# Altium schematic sheet Sensorbox_Hub_ESP32.SchDoc
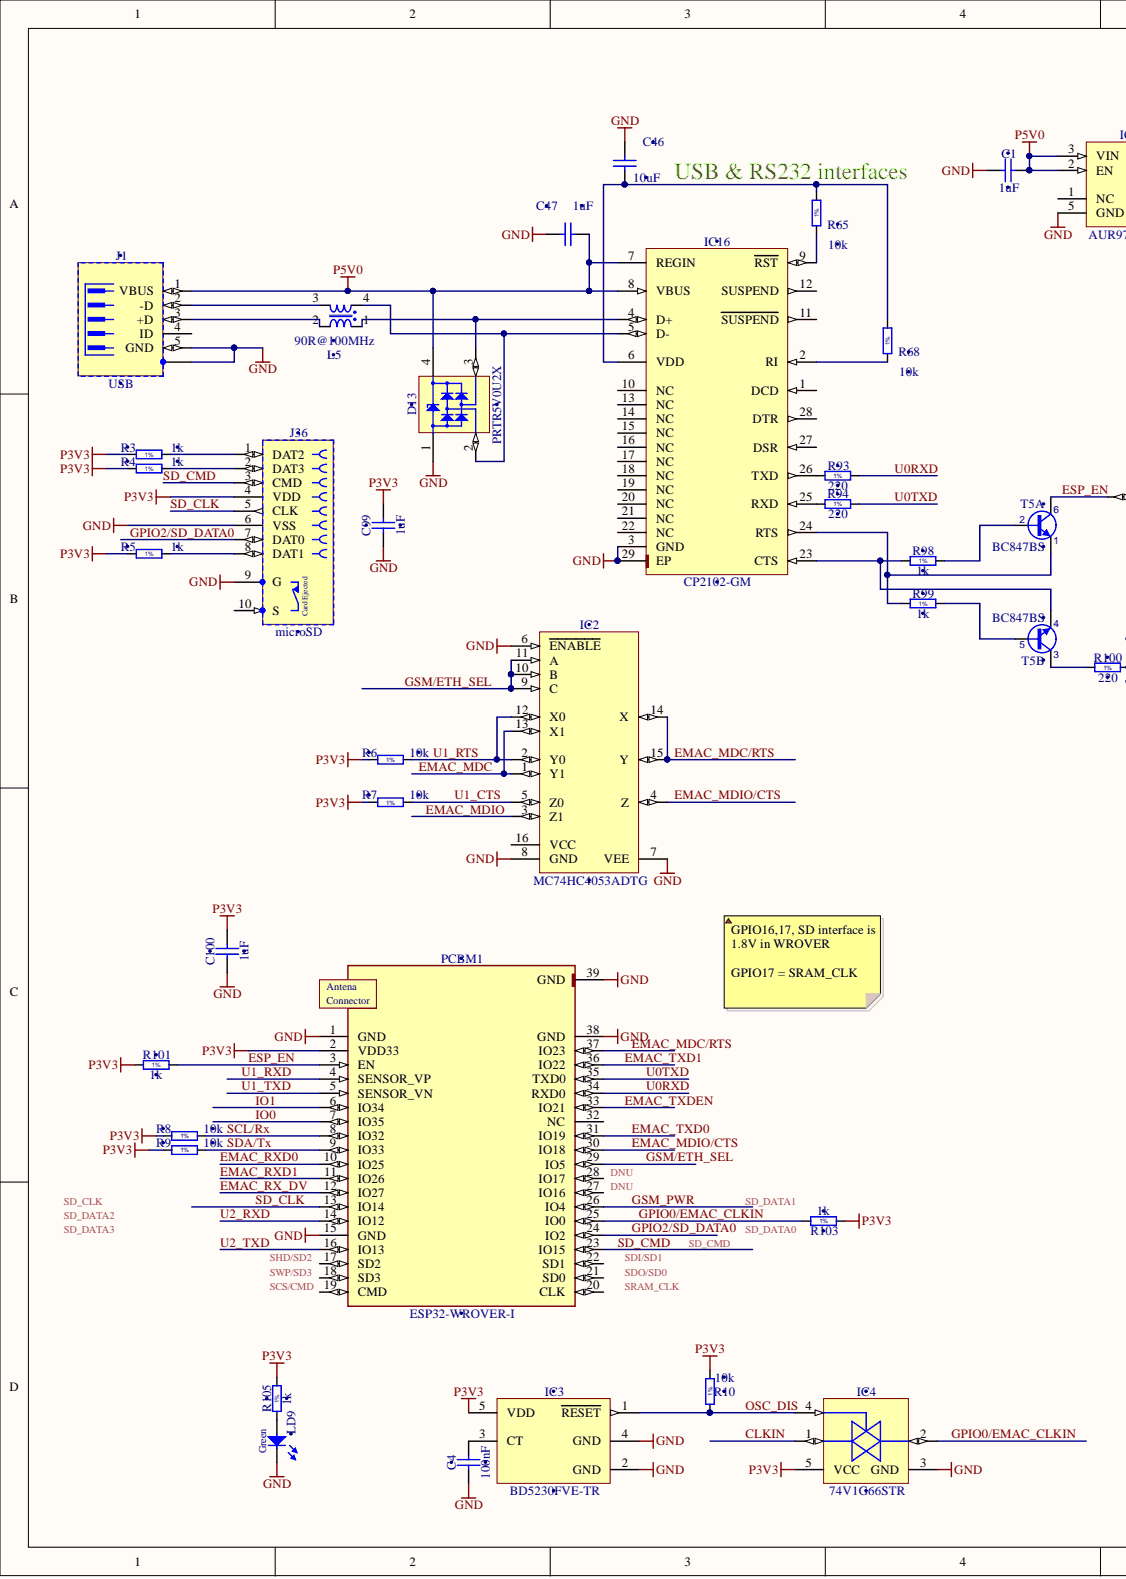
[diagram p0: Sensorbox_Hub_ESP32.SchDoc, left]
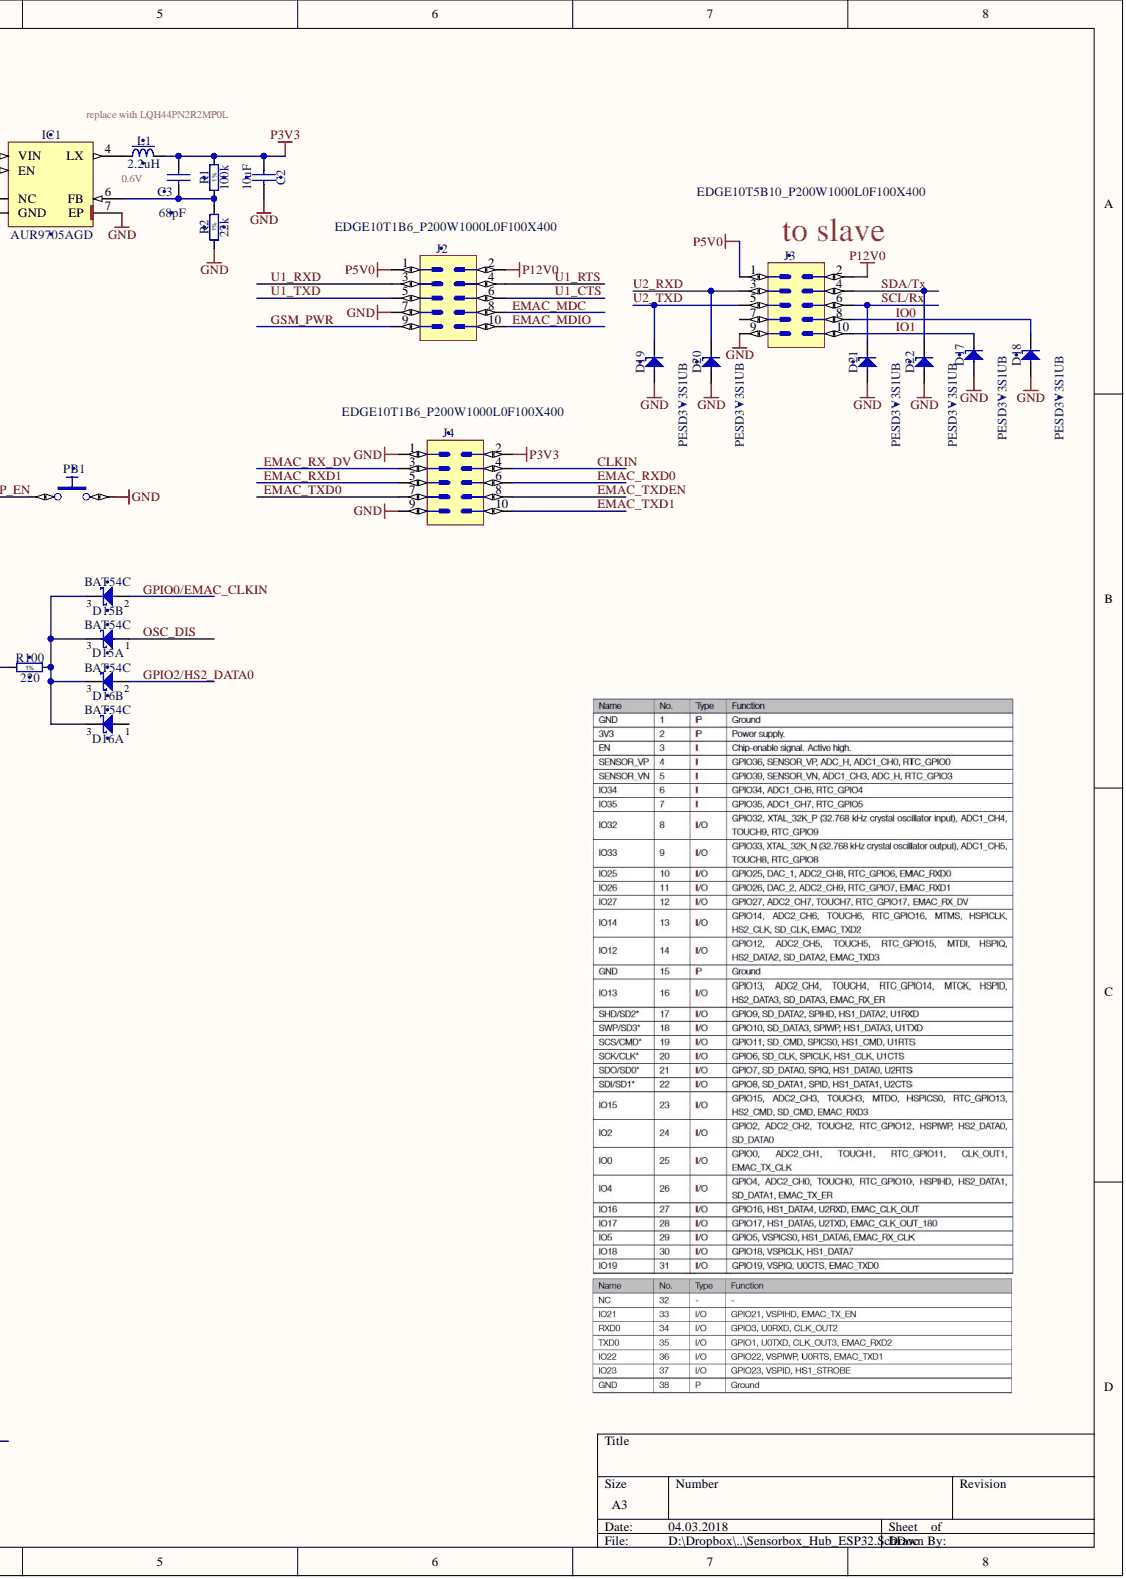
[diagram p1: Sensorbox_Hub_ESP32.SchDoc, right]

## Parts
C1  1uF  [CAPC1005X55N]
C2  10uF  [CAPC2012X135N]
C3  68pF  [CAPC1608X87N]
C4  100nF  [CAPC1005X55N]
C46  10uF  [CAPC3216X140N]
C47  1uF  [CAPC1608X87N]
C99  1uF  [CAPC1005X55N]
C100  1uF  [CAPC1005X55N]
D13  PRTR5V0U2X  [SOT190P230X110-4N]
D15  BAT54C  [SOT95P240X130-3N]  (parts A,B)
D16  BAT54C  [SOT95P240X130-3N]  (parts A,B)
D17  PESD3V3S1UB  [SODFL1608X65N]
D18  PESD3V3S1UB  [SODFL1608X65N]
D19  PESD3V3S1UB  [SODFL1608X65N]
D20  PESD3V3S1UB  [SODFL1608X65N]
D21  PESD3V3S1UB  [SODFL1608X65N]
D22  PESD3V3S1UB  [SODFL1608X65N]
IC1  AUR9705AGD  [SON65P200X200X80-7N-R65X145]
IC2  MC74HC4053ADTG  [SOP65P640X120-16N]
IC3  BD5230FVE-TR  [SOT95P280X145-5N]
IC4  74V1G66STR  [SOT95P280X145-5N]
IC16  CP2102-GM  [QFN50P500X500X100-29N-S335]
J1  USB  [MOLEX_105133-0011]
J2  Family  [EDGE10T1B6_P200W1000L0F100X400]  (value not drawn)  (no BOM)
J3  Family  [EDGE10T5B10_P200W1000L0F100X400]  (value not drawn)  (no BOM)
J4  Family  [EDGE10T1B6_P200W1000L0F100X400]  (value not drawn)  (no BOM)
J36  microSD  [ATTEND_112A-TAAR-R03]
L1  2.2uH  Manufacturer Part Number: LQH44PN2R2MP0L  [IND_MURATA_LQH44PN_P0]
L5  90R@100MHz  [IND_MURATA_DLW21S]
LD9  Green  [LED_KINGBRIGHT_KPTR3216]
PB1  Push Button  [PB_TYCO_FSMSM]  (value not drawn)
PCBM1  ESP32-WROVER-I  [PCBM_ESP32-WROVER-I]
R1  100k  [RESC1005X40N]
R2  22k  [RESC1005X40N]
R3  1k  [RESC1005X40N]
R4  1k  [RESC1005X40N]
R5  1k  [RESC1005X40N]
R6  10k  [RESC1005X40N]
R7  10k  [RESC1005X40N]
R8  10k  [RESC1005X40N]
R9  10k  [RESC1005X40N]
R10  10k  [RESC1005X40N]
R65  10k  [RESC1005X40N]
R68  10k  [RESC1005X40N]
R93  220  [RESC1005X40N]
R94  220  [RESC1005X40N]
R98  1k  [RESC1005X40N]
R99  1k  [RESC1005X40N]
R100  220  [RESC1005X40N]
R101  1k  [RESC1005X40N]
R103  1k  [RESC1005X40N]
R105  1k  [RESC1005X40N]
T5  BC847BS  [SOT65P210X110-6N]  (parts A,B)

## Nets
GND: C1.1 C2.2 C4.1 C46.1 C47.2 C99.1 C100.1 D13.1 D17.2 D18.2 D19.2 D20.2 D21.2 D22.2 IC1.5(GND) IC1.7(EP) IC2.6(ENABLE) IC2.7(VEE) IC2.8(GND) IC3.2(GND) IC3.4(GND) IC4.3(GND) IC16.3(GND) IC16.29(EP) J1.5(GND) J1.6 J2.7 J3.9 J4.1 J4.9 J36.6(VSS) J36.9(G) LD9.1 PB1.2 PCBM1.1(GND) PCBM1.15(GND) PCBM1.38(GND) PCBM1.39(GND) R2.1
P3V3: C2.1 C3.2 C99.2 C100.2 IC3.5(VDD) IC4.5(VCC) J4.2 J36.4(VDD) L1.2 PCBM1.2(VDD33) R1.2 R3.1 R4.1 R5.1 R6.2 R7.2 R8.2 R9.2 R10.1 R101.1 R103.1 R105.2
P5V0: C1.2 C47.1 D13.4 IC1.2(EN) IC1.3(VIN) IC16.7(REGIN) IC16.8(VBUS) J1.1(VBUS) J2.1 J3.1
P12V0: J2.2 J3.2
CLKIN: IC4.1 J4.4
EMAC_MDC: IC2.1(Y1) IC2.13(X1) J2.8
EMAC_MDC/RTS: IC2.14(X) IC2.15(Y) PCBM1.37(IO23)
EMAC_MDIO: IC2.3(Z1) J2.10
EMAC_MDIO/CTS: IC2.4(Z) PCBM1.30(IO18)
EMAC_RX_DV: J4.3 PCBM1.12(IO27)
EMAC_RXD0: J4.6 PCBM1.10(IO25)
EMAC_RXD1: J4.5 PCBM1.11(IO26)
EMAC_TXD0: J4.7 PCBM1.31(IO19)
EMAC_TXD1: J4.10 PCBM1.36(IO22)
EMAC_TXDEN: J4.8 PCBM1.33(IO21)
ESP_EN: PB1.1 PCBM1.3(EN) R101.2 T5.6
GPIO0/EMAC_CLKIN: D15.2 IC4.2 PCBM1.25(IO0) R103.2
GPIO2/HS2_DATA0: D16.2
GPIO2/SD_DATA0: J36.7(DAT0) PCBM1.24(IO2)
GSM/ETH_SEL: IC2.9(C) IC2.10(B) IC2.11(A) PCBM1.29(IO5)
GSM_PWR: J2.9 PCBM1.26(IO4)
IO0: D18.1 J3.8 PCBM1.7(IO35)
IO1: D17.1 J3.10 PCBM1.6(IO34)
OSC_DIS: D15.1 IC3.1(RESET) IC4.4 R10.2
SCL/Rx: D21.1 J3.6 PCBM1.8(IO32) R8.1
SD_CLK: J36.5(CLK) PCBM1.13(IO14)
SD_CMD: J36.3(CMD) PCBM1.23(IO15)
SDA/Tx: D22.1 J3.4 PCBM1.9(IO33) R9.1
U0RXD: PCBM1.34(RXD0) R93.2
U0TXD: PCBM1.35(TXD0) R94.2
U1_CTS: IC2.5(Z0) J2.6 R7.1
U1_RTS: IC2.2(Y0) IC2.12(X0) J2.4 R6.1
U1_RXD: J2.3 PCBM1.4(SENSOR_VP)
U1_TXD: J2.5 PCBM1.5(SENSOR_VN)
U2_RXD: D20.1 J3.3 PCBM1.14(IO12)
U2_TXD: D19.1 J3.5 PCBM1.16(IO13)
NetC3_1: C3.1 IC1.6(FB) R1.1 R2.2
NetC4_2: C4.2 IC3.3(CT)
NetC46_2: C46.2 IC16.6(VDD) R65.2 R68.2
NetD13_2: D13.2 IC16.5(D-) L5.4
NetD13_3: D13.3 IC16.4(D+) L5.1
NetD15_3: D15.3 D16.3 R100.2
NetIC1_4: IC1.4(LX) L1.1
NetIC16_2: IC16.2(RI) R68.1
NetIC16_9: IC16.9(RST) R65.1
NetIC16_23: IC16.23(CTS) R98.1 T5.4
NetIC16_24: IC16.24(RTS) R99.1 T5.1
NetIC16_25: IC16.25(RXD) R94.1
NetIC16_26: IC16.26(TXD) R93.1
NetJ1_2: J1.2(-D) L5.3
NetJ1_3: J1.3(+D) L5.2
NetJ36_1: J36.1(DAT2) R3.2
NetJ36_2: J36.2(DAT3) R4.2
NetJ36_8: J36.8(DAT1) R5.2
NetLD9_2: LD9.2 R105.1
NetR98_2: R98.2 T5.2
NetR99_2: R99.2 T5.5
NetR100_1: R100.1 T5.3

Not connected: D16 1; IC1 1(NC); IC2 16(VCC); IC16 1(DCD) 10(NC) 11(SUSPEND) 12(SUSPEND) 13(NC) 14(NC) 15(NC) 16(NC) 17(NC) 18(NC) 19(NC) 20(NC) 21(NC) 22(NC) 27(DSR) 28(DTR); J1 4(ID); J3 7; J36 10(S); PCBM1 17(SD2) 18(SD3) 19(CMD) 20(CLK) 21(SD0) 22(SD1) 27(IO16) 28(IO17) 32(NC)

## Library data of the parts
C1 C99 C100 = CC0402_1UF_16V_10%_X5R: Non-polarised capacitor; Manufacturer: GENERIC; Manufacturer1 Part Number: C1005X5R1C105KT; Part Description: SMD Multilayer Chip Ceramic Capacitor; Database Table Name: Capacitors SMD; TC: X5R; Tolerance: ±10%; Voltage: 16V; Case: 0402; Component Kind: Standard; Component Type: Standard; CreateDate: 25.10.2012; HelpURL: \\cern.ch\dfs\Applications\Altium\Datasheets\CC0402_X5R_TDK_C.pdf; LatestRevisionDate: 25.10.2012; Library Name: Capacitors.DbLib; Manufacturer1 ComponentHeight: 0.55mm; Manufacturer1 Example: TDK; Manufacturer Part Number: CC0402_1UF_16V_10%_X5R; Mounted: Yes; Part Number: CC0402_1UF_16V_10%_X5R; Pin Count: 2; Sense: No; SMD: Yes; Socket: No; Status: Preferred; Value: 1uF; Author: CERN DEM JLC
C2 = CC0805_10UF_16V_10%_X5R: Non-polarised capacitor; Manufacturer: GENERIC; Manufacturer1 Part Number: C0805C106K4PAC; Part Description: SMD Multilayer Chip Ceramic Capacitor; Database Table Name: Capacitors SMD; TC: X5R; Tolerance: ±10%; Voltage: 16V; Case: 0805; Component Kind: Standard; Component Type: Standard; CreateDate: 03.12.2007; HelpURL: \\cern.ch\dfs\Applications\Altium\Datasheets\CC1206_X5R_KEMET.pdf; LatestRevisionDate: 03.12.2007; Library Name: Capacitors.DbLib; Manufacturer1 ComponentHeight: 1.4mm; Manufacturer1 Example: KEMET; Manufacturer Part Number: CC0805_10UF_16V_10%_X5R; Mounted: Yes; Part Number: CC0805_10UF_16V_10%_X5R; Pin Count: 2; Sense: No; SMD: Yes; Socket: No; Status: Preferred; Value: 10uF; Author: CERN DEM JLC
C3 = CC0603_68PF_50V_5%_NP0: Non-polarised capacitor; Manufacturer: GENERIC; Manufacturer1 Part Number: 223886715689; Part Description: SMD Multilayer Chip Ceramic Capacitor; Database Table Name: Capacitors SMD; TC: C0G/NP0; Tolerance: ±5%; Voltage: 50V; Case: 0603; Component Kind: Standard; Component Type: Standard; CreateDate: 03.12.2007; HelpURL: \\cern.ch\dfs\Applications\Altium\Datasheets\CC0603_NP0_PHYCOMP.pdf; LatestRevisionDate: 03.12.2007; Library Name: Capacitors.DbLib; Manufacturer1 ComponentHeight: 0.87mm; Manufacturer1 Example: PHYCOMP; Manufacturer Part Number: CC0603_68PF_50V_5%_NP0; Mounted: Yes; Part Number: CC0603_68PF_50V_5%_NP0; Pin Count: 2; Sense: No; SMD: Yes; Socket: No; Status: Preferred; Value: 68pF; Author: CERN DEM JLC
C4 = CC0402_100NF_16V_10%_X5R: Non-polarised capacitor; Manufacturer: GENERIC; Manufacturer1 Part Number: GRM155R61C104KA88D; Part Description: SMD Multilayer Chip Ceramic Capacitor; Database Table Name: Capacitors SMD; TC: X5R; Tolerance: ±10%; Voltage: 16V; Case: 0402; Component Kind: Standard; Component Type: Standard; CreateDate: 10.12.2013; HelpURL: \\cern.ch\dfs\Applications\Altium\Datasheets\CC0402_X5R_MURATA_GRM.pdf; LatestRevisionDate: 10.12.2013; Library Name: Capacitors.DbLib; Manufacturer1 ComponentHeight: 0.55mm; Manufacturer1 Example: MURATA; Manufacturer Part Number: CC0402_100NF_16V_10%_X5R; Mounted: Yes; Part Number: CC0402_100NF_16V_10%_X5R; Pin Count: 2; Sense: No; SMD: Yes; Socket: No; Status: None; Value: 100nF; Author: CERN DEM JLC
C46 = CC1206_10UF_16V_10%_X7R: Non-polarised capacitor; Manufacturer: GENERIC; Manufacturer1 Part Number: C1206C106K4RAC; Part Description: SMD Multilayer Chip Ceramic Capacitor; Database Table Name: Capacitors SMD; TC: X7R; Tolerance: ±10%; Voltage: 16V; Case: 1206; Component Kind: Standard; Component Type: Standard; CreateDate: 2009-04-28; HelpURL: \\cern.ch\dfs\Applications\Altium\Datasheets\CC1206_X7R_KEMET.pdf; LatestRevisionDate: 2009-04-28; Library Name: Capacitors.DbLib; Manufacturer1 ComponentHeight: 1.8mm; Manufacturer1 Example: KEMET; Manufacturer Part Number: CC1206_10uF_16V_10%_X7R; Mounted: Yes; Part Number: CC1206_10UF_16V_10%_X7R; Pin Count: 2; SMD: Yes; Socket: No; Status: Preferred; Table: Capacitors SMD; Value: 10uF; Author: CERN DEM JLC
C47 = CC0603_1UF_25V_10%_X7R: Non-polarised capacitor; Manufacturer: GENERIC; Manufacturer1 Part Number: GRM188R71E105KA12D; Part Description: SMD Multilayer Chip Ceramic Capacitor; Database Table Name: Capacitors SMD; TC: X7R; Tolerance: ±10%; Voltage: 25V; Case: 0603; Component Kind: Standard; Component Type: Standard; CreateDate: 2011-01-18; HelpURL: \\cern.ch\dfs\Applications\Altium\Datasheets\CC0603_X7R_MURATA.pdf; LatestRevisionDate: 2011-01-18; Library Name: Capacitors.DbLib; Manufacturer1 ComponentHeight: 0.9mm; Manufacturer1 Example: MURATA; Manufacturer Part Number: CC0603_1UF_25V_10%_X7R; Mounted: Yes; Part Number: CC0603_1UF_25V_10%_X7R; Pin Count: 2; SMD: Yes; Socket: No; Status: None; Table: Capacitors SMD; Value: 1uF; Author: CERN DEM JLC
D13 = PRTR5V0U2X: Ultra Low Capacitance Double Rail-to-Rail ESD Protection Diode; Manufacturer: NXP SEMICONDUCTORS; Part Description: Ultra Low Capacitance (1pF) Double Rail-to-Rail ESD Protection Diode (8kV); Bonding: No; Database Table Name: Diodes; PressFit: No; Voltage: 5.5V; Case: SOT143B; ComponentHeight: 1.1mm; Component Kind: Standard; Component Type: Standard; CreateDate: 2011-03-22; Device: PRTR5V0U2X; HelpURL: \\cern.ch\dfs\Applications\Altium\Datasheets\PRTR5V0U2X.pdf; LatestRevisionDate: 2011-03-22; Library Name: ICs And Semiconductors.DbLib; Manufacturer Part Number: PRTR5V0U2X; Mounted: Yes; PackageDescription: SOT143B, SOT, 1.90mm Pitch; 4 Pin, 1.30mm W X 2.90mm L X 1.10mm H body, IPC Medium Density; Part Number: PRTR5V0U2X; Pin Count: 4; SMD: Yes; Socket: No; Table: Diodes; Author: CERN DEM JLC
D15 D16 = BAT54C: Dual Common Cathode Schottky Diodes; Manufacturer: FAIRCHILD SEMICONDUCTOR; Part Description: Dual Common Cathode Small Signal Schottky Diode; Bonding: No; Power: 200mA; PressFit: No; Voltage: 30V; Case: SOT23-3; ComponentHeight: 1.30mm; Component Kind: Standard; Component Type: Standard; CreateDate: 17.09.2009; Database Table Name: Diodes; Device: BAT54C; HelpURL: \\cern.ch\dfs\Applications\Altium\Datasheets\BAT54C.pdf; LatestRevisionDate: 27.05.2010; Library Name: ICs And Semiconductors.DbLib; Manufacturer Part Number: BAT54C; Mounted: Yes; PackageDescription: SOT23 3-Leads, Pitch 0.95mm - Body 1.3x2.9mm, IPC Medium Density; Part Number: BAT54C; Pin Count: 3; Sense: No; SMD: Yes; Socket: No; Author: CERN DEM JLC
D17-D22 = PESD3V3S1UB: ESD protection diode; Manufacturer: NXP SEMICONDUCTORS; Part Description: ESD protection diode (23kV); Bonding: No; Database Table Name: Diodes; Power: 330W; PressFit: No; Voltage: 3V3; Case: SOD523; ComponentHeight: 0.65mm; Component Kind: Standard; Component Type: Standard; CreateDate: 29.11.2012; Device: PESD3V3S1UB; HelpURL: \\cern.ch\dfs\Applications\Altium\Datasheets\PESD3V3S1UB.pdf; LatestRevisionDate: 29.11.2012; Library Name: ICs And Semiconductors.DbLib; Manufacturer Part Number: PESD3V3S1UB; Mounted: Yes; PackageDescription: Ultra Small Outline Diode Flat Lead (SOD-523), Body; 1.2mm L X 0.8mm W X 0.65mm H, IPC Medium Density; Part Number: PESD3V3S1UB; Pin Count: 2; Sense: No; SMD: Yes; Socket: No; Author: CERN DEM JLC
IC1 = AUR9705AGD: 0V to 6V Input, 1A, 1.5MHz, Step-Down DC-DC Converter; Manufacturer: DIODES INCORPORATED; Bonding: No; Database Table Name: Regulators; PressFit: No; Case: SON6; ComponentHeight: 0.8mm; Component Kind: Standard; Component Type: Standard; CreateDate: 15.02.2018; Device: AUR9705AGD; HelpURL: \\cern.ch\dfs\Applications\Altium\Datasheets\AUR9705AGD.pdf; LatestRevisionDate: 15.02.2018; Library Name: ICs And Semiconductors.DbLib; Manufacturer Part Number: AUR9705AGD; Mounted: Yes; PackageDescription: SON, 0.65mm Pitch, 6 Pin, 2.00mm W X 2.00mm L X 0.80mm H body (W/Thermal Tab 0.65mm X 1.45mm), IPC Medium Density; Part Description: 0V to 6V Input, 1A, 1.5MHz, Step-Down DC-DC Converter; Part Number: AUR9705AGD; Pin Count: 7; Sense: No; SMD: Yes; Socket: No; Author: CERN DEM MR
IC2 = MC74HC4053ADTG: Triple 2-Channel Analog Multiplexer/Demultiplexer; Manufacturer: ON SEMICONDUCTOR; Bonding: No; Database Table Name: Standard Logic; PressFit: No; Case: TSSOP16; ComponentHeight: 1.20mm; Component Kind: Standard; Component Type: Standard; CreateDate: 16.02.2018; Device: MC74HC4053ADTG; HelpURL: \\cern.ch\dfs\Applications\Altium\Datasheets\MC74HC4053ADTG.pdf; LatestRevisionDate: 16.02.2018; Library Name: ICs And Semiconductors.DbLib; Manufacturer Part Number: MC74HC4053ADTG; Mounted: Yes; PackageDescription: SOP, 0.65mm Pitch; 16 pin, 4.40mm W X 5.00mm L X 1.20mm H body IPC Medium Density; Part Description: Triple 2-Channel Analog Multiplexer/Demultiplexer; Part Number: MC74HC4053ADTG; Pin Count: 16; Sense: No; SMD: Yes; Socket: No; Author: CERN DEM MR
IC3 = (no symbol name): Free Delay Time Setting  CMOS Voltage Detector; Manufacturer: Rohm; Part Description: 5V, Low Quiescent Current, Programmable-Delay Supervisory Circuit; Bonding: No; PressFit: No; Case: SOT23-5; ComponentHeight: 1.45mm; Component Kind: Standard; Component Type: Standard; CreateDate: 02.03.2015; Device: BD5230FVE-TR; HelpURL: \\cern.ch\dfs\Applications\Altium\Datasheets\TPS3808G50DBVT.pdf; LatestRevisionDate: 02.03.2015; Library Name: LIBRARY_SCH.SCHLIB; Manufacturer Part Number: BD5230FVE-TR; Mounted: Yes; PackageDescription: SOT23, 5-Leads, Body 1.6x3.0mm, Pitch 0.95mm, IPC Medium Density; Part Number: BD5230FVE-TR; Pin Count: 5; Sense: No; SMD: Yes; Socket: No; Author: GK
IC4 = 74V1G66STR: Single Bilateral Switch; Manufacturer: ST MICROELECTRONICS; Bonding: No; Database Table Name: Standard Logic; PressFit: No; Case: SOT23-5; ComponentHeight: 1.45mm; Component Kind: Standard; Component Type: Standard; CreateDate: 20.01.2014; Device: 74V1G66STR; HelpURL: \\cern.ch\dfs\Applications\Altium\Datasheets\74V1G66STR.pdf; LatestRevisionDate: 20.01.2014; Library Name: ICs And Semiconductors.DbLib; Manufacturer Part Number: 74V1G66STR; Mounted: Yes; PackageDescription: SOT, 0.95mm pitch; 5 pin, 1.60mm W X 2.90mm L X 1.45mm H body, IPC Medium Density; Part Description: Single Bilateral Switch; Part Number: 74V1G66STR; Pin Count: 5; Sense: No; SMD: Yes; Socket: No; Author: CERN DEM JLC
IC16 = CP2102-GM: Single-Chip USB to UART Bridge; Manufacturer: SILICON LABS; Database Table Name: Analog & Interface; Case: QFN28; ComponentHeight: 1.0mm; Component Kind: Standard; Component Type: Standard; CreateDate: 2011-03-03; Device: CP2102-GM; HelpURL: \\cern.ch\dfs\Applications\Altium\Datasheets\CP2102-GM.pdf; LatestRevisionDate: 2011-03-03; Library Name: ICs And Semiconductors.DbLib; Manufacturer Part Number: CP2102-GM; Mounted: Yes; PackageDescription: QFN, 0.50mm Pitch, Square; 28 pin, 5.00mm L X 5.00mm W X 1.00mm H body (w/thermal tab 3.35 X 3.35mm),  IPC Medium Density; Part Description: Single-Chip USB to UART Bridge; Part Number: CP2102-GM; Pin Count: 29; SMD: Yes; Socket: No; Table: Analog & Interface; Author: CERN DEM JLC
J1 = MOLEX_105133-0011: 5 Pin + 1 Shield USB Receptacle; Part Description: 0.65mm Pitch, Micro-USB Type B Surface Mounte Vertical Receptacle; Database Table Name: MOLEX; PressFit: No; ComponentHeight: 6.7mm; Component Kind: Standard; Component Type: Standard; CreateDate: 13.12.2017; Family: USB; HelpURL: \\cern.ch\dfs\Applications\Altium\Datasheets\MOLEX_105133-0011.pdf; LatestRevisionDate: 13.12.2017; Library Name: Connectors.DbLib; Manufacturer: MOLEX; Manufacturer Part Number: 105133-0011; Mounted: Yes; Part Number: MOLEX_105133-0011; Pin Count: 8; Sense: No; SMD: Yes; Socket: No; Status: None; Author: CERN DEM MR
J2 J4 = EDGE10T1B6_P200W1000L0F100X400: PCB Edge Connector 10 (2x5); Part Description: 10 Contacts Board Edge Connector, Pitch 2mm, Length 0mm, Width 10mm, Finger Lenght 4mm, Finger Width 1mm; Database Table Name: Miscellaneous; Mounted: No; PressFit: No; ComponentHeight: 0mm; Component Type: Standard (No BOM); CreateDate: 14.02.2018; HelpURL: \\cern.ch\dfs\Applications\Altium\Datasheets\EDGE10T1B6_P200W1000L0F100X400.pdf; LatestRevisionDate: 14.02.2018; Library Name: Connectors.DbLib; Part Number: EDGE10T1B6_P200W1000L0F100X400; Pin Count: 10; Sense: No; SMD: No; Socket: No; Status: None; Author: CERN DEM MR
J3 = EDGE10T5B10_P200W1000L0F100X400: PCB Edge Connector 10 (2x5); Part Description: 10 Contacts Board Edge Connector, Pitch 2mm, Length 0mm, Width 10mm, Finger Lenght 4mm, Finger Width 1mm; Database Table Name: Miscellaneous; Mounted: No; PressFit: No; ComponentHeight: 0mm; Component Type: Standard (No BOM); CreateDate: 14.02.2018; HelpURL: \\cern.ch\dfs\Applications\Altium\Datasheets\EDGE10T5B10_P200W1000L0F100X400.pdf; LatestRevisionDate: 14.02.2018; Library Name: Connectors.DbLib; Part Number: EDGE10T5B10_P200W1000L0F100X400; Pin Count: 10; Sense: No; SMD: No; Socket: No; Status: None; Author: CERN DEM MR
J36 = ATTEND_112A-TAAR-R03: Connector MicroSD 8 Pin + Switch; Manufacturer: ATTEND; Part Description: MicroSD Socket, Push-Push Type; Database Table Name: Miscellaneous; PressFit: No; ComponentHeight: 1.9mm; Component Kind: Standard; Component Type: Standard; CreateDate: 07.07.2015; Family: microSD; HelpURL: \\cern.ch\dfs\Applications\Altium\Datasheets\ATTEND_112A-TAAR-R03.pdf; LatestRevisionDate: 07.07.2015; Library Name: Connectors.DbLib; Manufacturer Part Number: 112A-TAAR-R03; Mounted: Yes; Part Number: ATTEND_112A-TAAR-R03; Pin Count: 10; Sense: No; SMD: Yes; Socket: No; Status: None; Author: CERN DEM JMW
L1 = IND_2.2UH_20%_MURATA_LQH44PN2R2MP0L: Inductor With Core; Manufacturer: MURATA; Part Description: Wire Wound Ferrite Inductor for Power Lines; Database Table Name: Inductors SMD; Power: 1.8A; Resistance: 0R049; Tolerance: ±20%; Case: 4040; ComponentHeight: 1.8mm; Component Kind: Standard; Component Type: Standard; CreateDate: 14.02.2018; HelpURL: \\cern.ch\dfs\Applications\Altium\Datasheets\IND_MURATA_LQH44PN_P0.pdf; LatestRevisionDate: 14.02.2018; Library Name: Inductors & Transformers.DbLib; Mounted: Yes; Part Number: IND_2.2UH_20%_MURATA_LQH44PN2R2MP0L; Pin Count: 2; Sense: No; SMD: Yes; Socket: No; Status: None; Value: 2.2uH; Author: CERN DEM JLC
L5 = IND_MURATA_DLW21SN900SQ2L: Inductor Common Mode; Manufacturer: MURATA; Part Description: Wire Wound Common Mode Choke Coil (DLW21S Series); Database Table Name: Inductors SMD; Power: 330mA; Resistance: 0.35R; Tolerance: ±25%; Case: 0805; ComponentHeight: 1.4mm; Component Kind: Standard; Component Type: Standard; CreateDate: 2014-07-01; HelpURL: \\cern.ch\dfs\Applications\Altium\Datasheets\IND_MURATA_DLW21S.pdf; LatestRevisionDate: 2014-07-01; Library Name: Inductors & Transformers.DbLib; Manufacturer Part Number: DLW21SN900SQ2L; Mounted: Yes; Part Number: IND_MURATA_DLW21SN900SQ2L; Pin Count: 4; SMD: Yes; Socket: No; Status: None; Table: Inductors SMD; Value: 90R@100MHz; Author: CERN DEM JLC
LD9 = LED_KINGBRIGHT_KPTR-3216CGCK: LED Green 1A 2C; Part Description: Reverse Mount LED 1.4x1.6mm Green; Bonding: No; Database Table Name: LEDs & Displays; PressFit: No; Color: Green; ComponentHeight: 0.35mm; Component Kind: Standard; Component Type: Standard; CreateDate: 15.02.2016; HelpURL: \\cern.ch\dfs\Applications\Altium\Datasheets\LED_KINGBRIGHT_KPTR-3216CGCK.pdf; LatestRevisionDate: 15.02.2016; Library Name: ICs And Semiconductors.DbLib; Manufacturer: KINGBRIGHT; Manufacturer Part Number: KPTR-3216CGCK; Mounted: Yes; PackageDescription: Reverse Mount LED 3216, Hole 2.1x2.3mm 0.35mm Height; Part Number: LED_KINGBRIGHT_KPTR-3216CGCK; Pin Count: 2; Sense: No; SMD: Yes; Socket: No; Author: CERN DEM MR
PB1 = PB_TYCO_FSMSM: Push-Button Switch SPDT; Manufacturer: TYCO ELECTRONICS; Manufacturer Part Number: FSMSM or 1437566-3; Part Description: 12VDC 50mA SPST Tactile  Push-Button Switch; Database Table Name: Switches; PressFit: No; ComponentHeight: 4.3mm; Component Kind: Standard; Component Type: Standard; CreateDate: 30.06.2008; Family: Push Button; HelpURL: \\cern.ch\dfs\Applications\Altium\Datasheets\PB_TYCO_FSMSM.pdf; LatestRevisionDate: 21.06.2012; Library Name: Eletro-mechanical.DbLib; Mounted: Yes; PackageDescription: Body 3.5x6.0mm, Pushbutton Switch,  TYCO ALCOSWITCH FSMSM Serie; Part Number: PB_TYCO_FSMSM; Pin Count: 2; Sense: No; SMD: Yes; Socket: No; Status: None; Author: CERN DEM JLC
PCBM1 = PCBM_ESP32-WROVER-I: Generic WiFi-BT-BLE MCU Module; Manufacturer: ESPRESSIF; Part Description: Generic WiFi-BT-BLE MCU Module (IPEX antenna, 32-Mbit PSRAM); Bonding: No; Database Table Name: PCB Modules; PressFit: No; ComponentHeight: 3.65mm; Component Kind: Standard; Component Type: Standard; CreateDate: 14.11.2017; Device: ESP32-WROVER-I; HelpURL: \\cern.ch\dfs\Applications\Altium\Datasheets\PCBM_ESP32-WROVER-I.pdf; LatestRevisionDate: 14.11.2017; Library Name: ICs And Semiconductors.DbLib; Manufacturer Part Number: ESP32-WROVER-I; Mounted: Yes; PackageDescription: Generic WiFi-BT-BLE MCU Module (IPEX antenna) ,Body 18.00mm x 31.4mm; Part Number: PCBM_ESP32-WROVER-I; Pin Count: 39; Sense: No; SMD: Yes; Socket: No; Author: CERN DEM JLC
R1 = R0402_100K_1%_0.0625W_100PPM: Resistor - 1%; Manufacturer: GENERIC; Manufacturer1 Part Number: 232270671004L; Part Description: General Purpose Thick Film Chip Resistor; Database Table Name: Resistors SMD; PressFit: No; TC: ±100ppm/°C; Case: 0402; Component Kind: Standard; Component Type: Standard; CreateDate: 03.12.2007; HelpURL: \\cern.ch\dfs\Applications\Altium\Datasheets\R0402_Phycomp_RC0402.pdf; LatestRevisionDate: 17.10.2012; Library Name: Resistors.DbLib; Manufacturer1 ComponentHeight: 0.4mm; Manufacturer1 Example: YAGEO PHYCOMP; Manufacturer Part Number: R0402_100K_1%_0.0625W_100PPM; Mounted: Yes; Part Number: R0402_100K_1%_0.0625W_100PPM; Pin Count: 2; Power: 0.0625W; Sense: No; SMD: Yes; Socket: No; Status: Not Recommended; Tolerance: ±1%; Value: 100k; Author: CERN DEM JLC
R2 = R0402_22K_1%_0.0625W_100PPM: Resistor - 1%; Manufacturer: GENERIC; Manufacturer1 Part Number: 232270672203L; Part Description: General Purpose Thick Film Chip Resistor; Database Table Name: Resistors SMD; PressFit: No; TC: ±100ppm/°C; Case: 0402; Component Kind: Standard; Component Type: Standard; CreateDate: 03.12.2007; HelpURL: \\cern.ch\dfs\Applications\Altium\Datasheets\R0402_Phycomp_RC0402.pdf; LatestRevisionDate: 17.10.2012; Library Name: Resistors.DbLib; Manufacturer1 ComponentHeight: 0.4mm; Manufacturer1 Example: YAGEO PHYCOMP; Manufacturer Part Number: R0402_22K_1%_0.0625W_100PPM; Mounted: Yes; Part Number: R0402_22K_1%_0.0625W_100PPM; Pin Count: 2; Power: 0.0625W; Sense: No; SMD: Yes; Socket: No; Status: Not Recommended; Tolerance: ±1%; Value: 22k; Author: CERN DEM JLC
R3-R5 R98 R99 R101 R103 R105 = R0402_1K_1%_0.0625W_100PPM: Resistor - 1%; Manufacturer: GENERIC; Manufacturer1 Part Number: 232270671002L; Part Description: General Purpose Thick Film Chip Resistor; Database Table Name: Resistors SMD; PressFit: No; TC: ±100ppm/°C; Case: 0402; Component Kind: Standard; Component Type: Standard; CreateDate: 03.12.2007; HelpURL: \\cern.ch\dfs\Applications\Altium\Datasheets\R0402_Phycomp_RC0402.pdf; LatestRevisionDate: 17.10.2012; Library Name: Resistors.DbLib; Manufacturer1 ComponentHeight: 0.4mm; Manufacturer1 Example: YAGEO PHYCOMP; Manufacturer Part Number: R0402_1K_1%_0.0625W_100PPM; Mounted: Yes; Part Number: R0402_1K_1%_0.0625W_100PPM; Pin Count: 2; Power: 0.0625W; Sense: No; SMD: Yes; Socket: No; Status: Not Recommended; Tolerance: ±1%; Value: 1k; Author: CERN DEM JLC
R6-R10 R65 R68 = R0402_10K_1%_0.0625W_100PPM: Resistor - 1%; Manufacturer: GENERIC; Manufacturer1 Part Number: 232270671003L; Part Description: General Purpose Thick Film Chip Resistor; Database Table Name: Resistors SMD; TC: ±100ppm/°C; Case: 0402; Component Kind: Standard; Component Type: Standard; CreateDate: 2007-12-03; HelpURL: \\cern.ch\dfs\Applications\Altium\Datasheets\R0402_Phycomp_RC0402.pdf; LatestRevisionDate: 2012-10-17; Library Name: Resistors.DbLib; Manufacturer1 ComponentHeight: 0.4mm; Manufacturer1 Example: YAGEO PHYCOMP; Manufacturer Part Number: R0402_10K_1%_0.0625W_100PPM; Mounted: Yes; Part Number: R0402_10K_1%_0.0625W_100PPM; Pin Count: 2; Power: 0.0625W; SMD: Yes; Socket: No; Status: Not recommended; Table: Resistors SMD; Tolerance: ±1%; Value: 10k; Author: CERN DEM JLC
R93 R94 R100 = R0402_220R_1%_0.0625W_100PPM: Resistor - 1%; Manufacturer: GENERIC; Manufacturer1 Part Number: 232270672201L; Part Description: General Purpose Thick Film Chip Resistor; Database Table Name: Resistors SMD; PressFit: No; TC: ±100ppm/°C; Case: 0402; Component Kind: Standard; Component Type: Standard; CreateDate: 03.12.2007; HelpURL: \\cern.ch\dfs\Applications\Altium\Datasheets\R0402_Phycomp_RC0402.pdf; LatestRevisionDate: 17.10.2012; Library Name: Resistors.DbLib; Manufacturer1 ComponentHeight: 0.4mm; Manufacturer1 Example: YAGEO PHYCOMP; Manufacturer Part Number: R0402_220R_1%_0.0625W_100PPM; Mounted: Yes; Part Number: R0402_220R_1%_0.0625W_100PPM; Pin Count: 2; Power: 0.0625W; Sense: No; SMD: Yes; Socket: No; Status: Not Recommended; Tolerance: ±1%; Value: 220; Author: CERN DEM JLC
T5 = BC847BS: 45V 100mA Dual NPN General-Purpose Transistor; Manufacturer: NXP SEMICONDUCTORS; Bonding: No; Database Table Name: Transistors; PressFit: No; Case: SOT363; ComponentHeight: 1.1mm; Component Kind: Standard; Component Type: Standard; CreateDate: 10.07.2015; Device: BC847BS; HelpURL: \\cern.ch\dfs\Applications\Altium\Datasheets\BC847BS.pdf; LatestRevisionDate: 10.07.2015; Library Name: ICs And Semiconductors.DbLib; Manufacturer Part Number: BC847BS; Mounted: Yes; PackageDescription: SOT363, SC-88, SOT, 0.65mm Pitch, 6 pin, 1.25mm W X 2.00mm L X 1.10mm H, IPC Medium Density; Part Description: 45V 100mA Dual NPN General-Purpose Transistor; Part Number: BC847BS; Pin Count: 6; Sense: No; SMD: Yes; Socket: No; Author: CERN DEM JMW
